# Altium schematic sheet PIN(7~8).SchDoc(PIN(7~8))
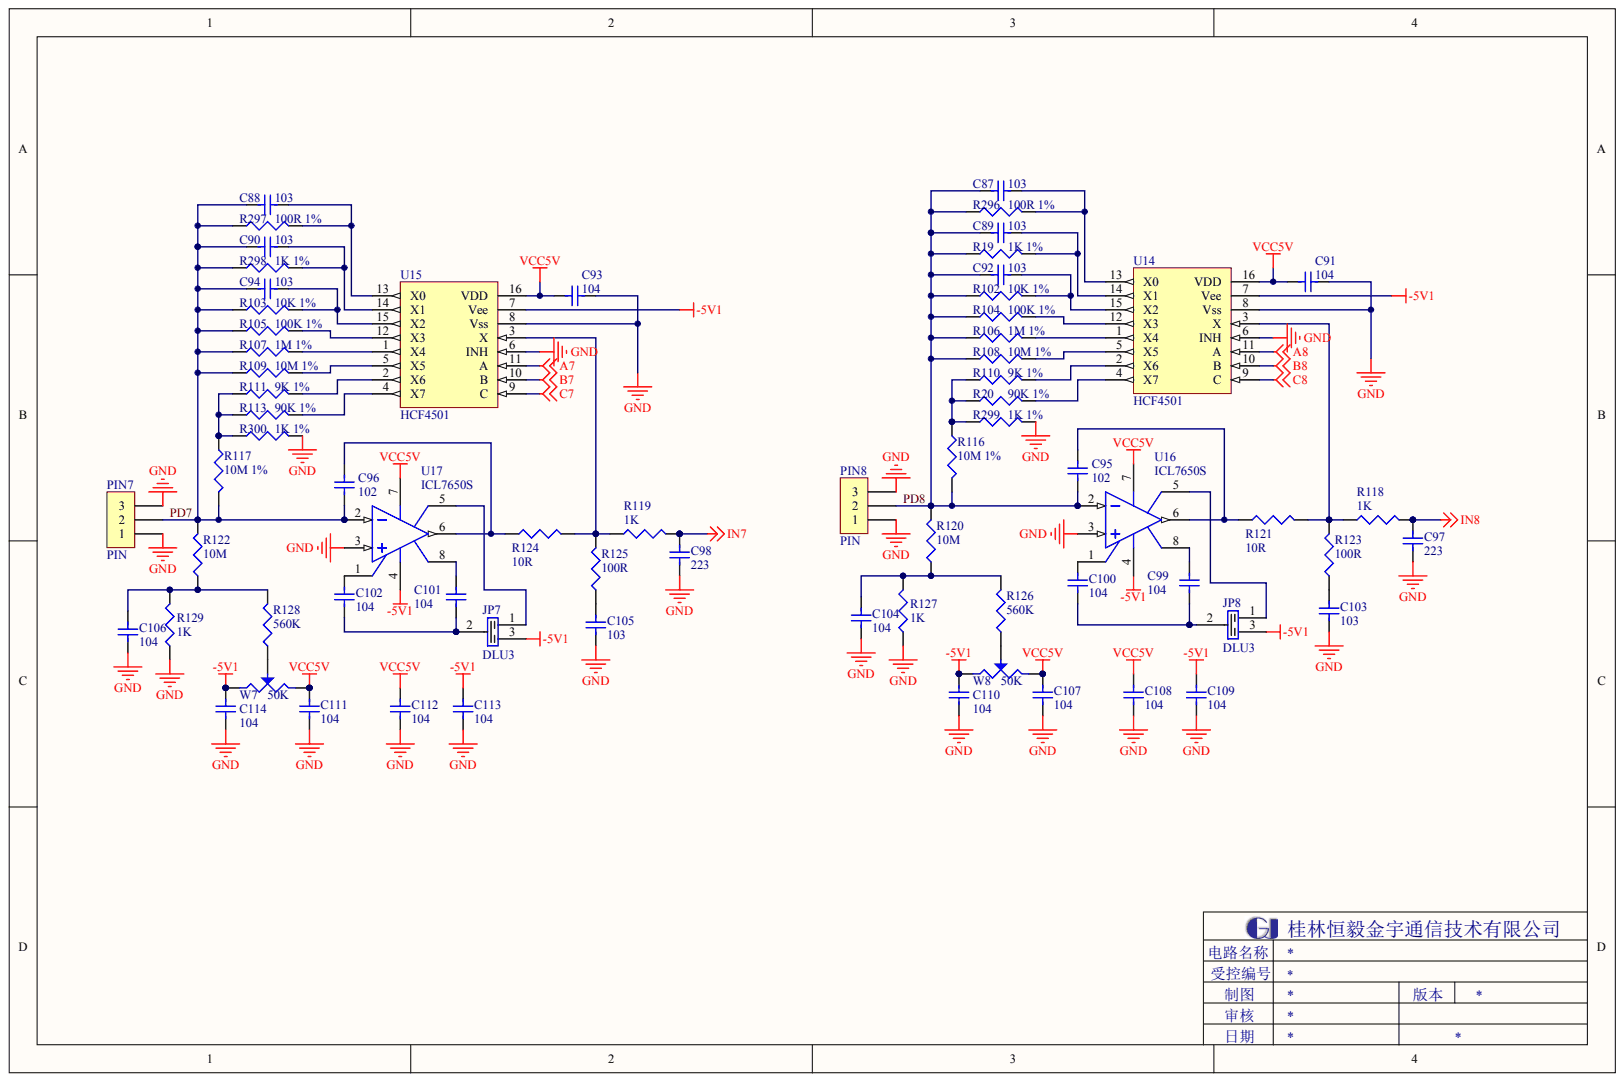
[diagram p0: PIN]

## Parts
C87  103
C88  103
C89  103
C90  103
C91  104
C92  103
C93  104
C94  103
C95  102
C96  102
C97  223
C98  223
C99  104
C100  104
C101  104
C102  104
C103  103
C104  104
C105  103
C106  104
C107  104
C108  104
C109  104
C110  104
C111  104
C112  104
C113  104
C114  104
JP7  DLU3
JP8  DLU3
PIN7  PIN
PIN8  PIN
R19  1K 1%
R20  90K 1%
R102  10K 1%
R103  10K 1%
R104  100K 1%
R105  100K 1%
R106  1M 1%
R107  1M 1%
R108  10M 1%
R109  10M 1%
R110  9K 1%
R111  9K 1%
R113  90K 1%
R116  10M 1%
R117  10M 1%
R118  1K
R119  1K
R120  10M
R121  10R
R122  10M
R123  100R
R124  10R
R125  100R
R126  560K
R127  1K
R128  560K
R129  1K
R296  100R 1%
R297  100R 1%
R298  1K 1%
R299  1K 1%
R300  1K 1%
U14  HCF4501
U15  HCF4501
U16  ICL7650S
U17  ICL7650S
W7  50K
W8  50K

## Nets
-5V1: C109.2 C110.1 C113.2 C114.1 JP7.3 JP8.3 U14.7(Vee) U15.7(Vee) U16.4 U17.4 W7.1 W8.1
A7: U15.11(A)
A8: U14.11(A)
B7: U15.10(B)
B8: U14.10(B)
C7: U15.9(C)
C8: U14.9(C)
GND: C91.2 C93.2 C97.1 C98.1 C103.1 C104.1 C105.1 C106.1 C107.1 C108.1 C109.1 C110.2 C111.1 C112.1 C113.1 C114.2 PIN7.1 PIN7.3 PIN8.1 PIN8.3 R127.1 R129.1 R299.2 R300.2 U14.6(INH) U14.8(Vss) U15.6(INH) U15.8(Vss) U16.3 U17.3
IN7: C98.2 R119.2
IN8: C97.2 R118.2
VCC5V: C91.1 C93.1 C107.2 C108.2 C111.2 C112.2 U14.16(VDD) U15.16(VDD) U16.7 U17.7 W7.3 W8.3
PD7: C88.1 C90.1 C94.1 C96.1 PIN7.2 R103.1 R105.1 R107.1 R109.1 R117.2 R122.2 R297.1 R298.1 U17.2
PD8: C87.1 C89.1 C92.1 C95.1 PIN8.2 R19.1 R102.1 R104.1 R106.1 R108.1 R116.2 R120.2 R296.1 U16.2
NetC87_2: C87.2 R296.2 U14.13(X0)
NetC88_2: C88.2 R297.2 U15.13(X0)
NetC89_2: C89.2 R19.2 U14.14(X1)
NetC90_2: C90.2 R298.2 U15.14(X1)
NetC92_2: C92.2 R102.2 U14.15(X2)
NetC94_2: C94.2 R103.2 U15.15(X2)
NetC95_2: C95.2 R121.1 U16.6
NetC96_2: C96.2 R124.1 U17.6
NetC99_1: C99.1 U16.8
NetC99_2: C99.2 C100.1 JP8.2
NetC100_2: C100.2 U16.1
NetC101_1: C101.1 U17.8
NetC101_2: C101.2 C102.1 JP7.2
NetC102_2: C102.2 U17.1
NetC103_2: C103.2 R123.1
NetC104_2: C104.2 R120.1 R126.2 R127.2
NetC105_2: C105.2 R125.1
NetC106_2: C106.2 R122.1 R128.2 R129.2
NetJP7_1: JP7.1 U17.5
NetJP8_1: JP8.1 U16.5
NetR20_1: R20.1 R110.1 R116.1 R299.1
NetR20_2: R20.2 U14.4(X7)
NetR104_2: R104.2 U14.12(X3)
NetR105_2: R105.2 U15.12(X3)
NetR106_2: R106.2 U14.1(X4)
NetR107_2: R107.2 U15.1(X4)
NetR108_2: R108.2 U14.5(X5)
NetR109_2: R109.2 U15.5(X5)
NetR110_2: R110.2 U14.2(X6)
NetR111_1: R111.1 R113.1 R117.1 R300.1
NetR111_2: R111.2 U15.2(X6)
NetR113_2: R113.2 U15.4(X7)
NetR118_1: R118.1 R121.2 R123.2 U14.3(X)
NetR119_1: R119.1 R124.2 R125.2 U15.3(X)
NetR126_1: R126.1 W8.2
NetR128_1: R128.1 W7.2
